# Altium schematic sheet Main_Schematic.SchDoc
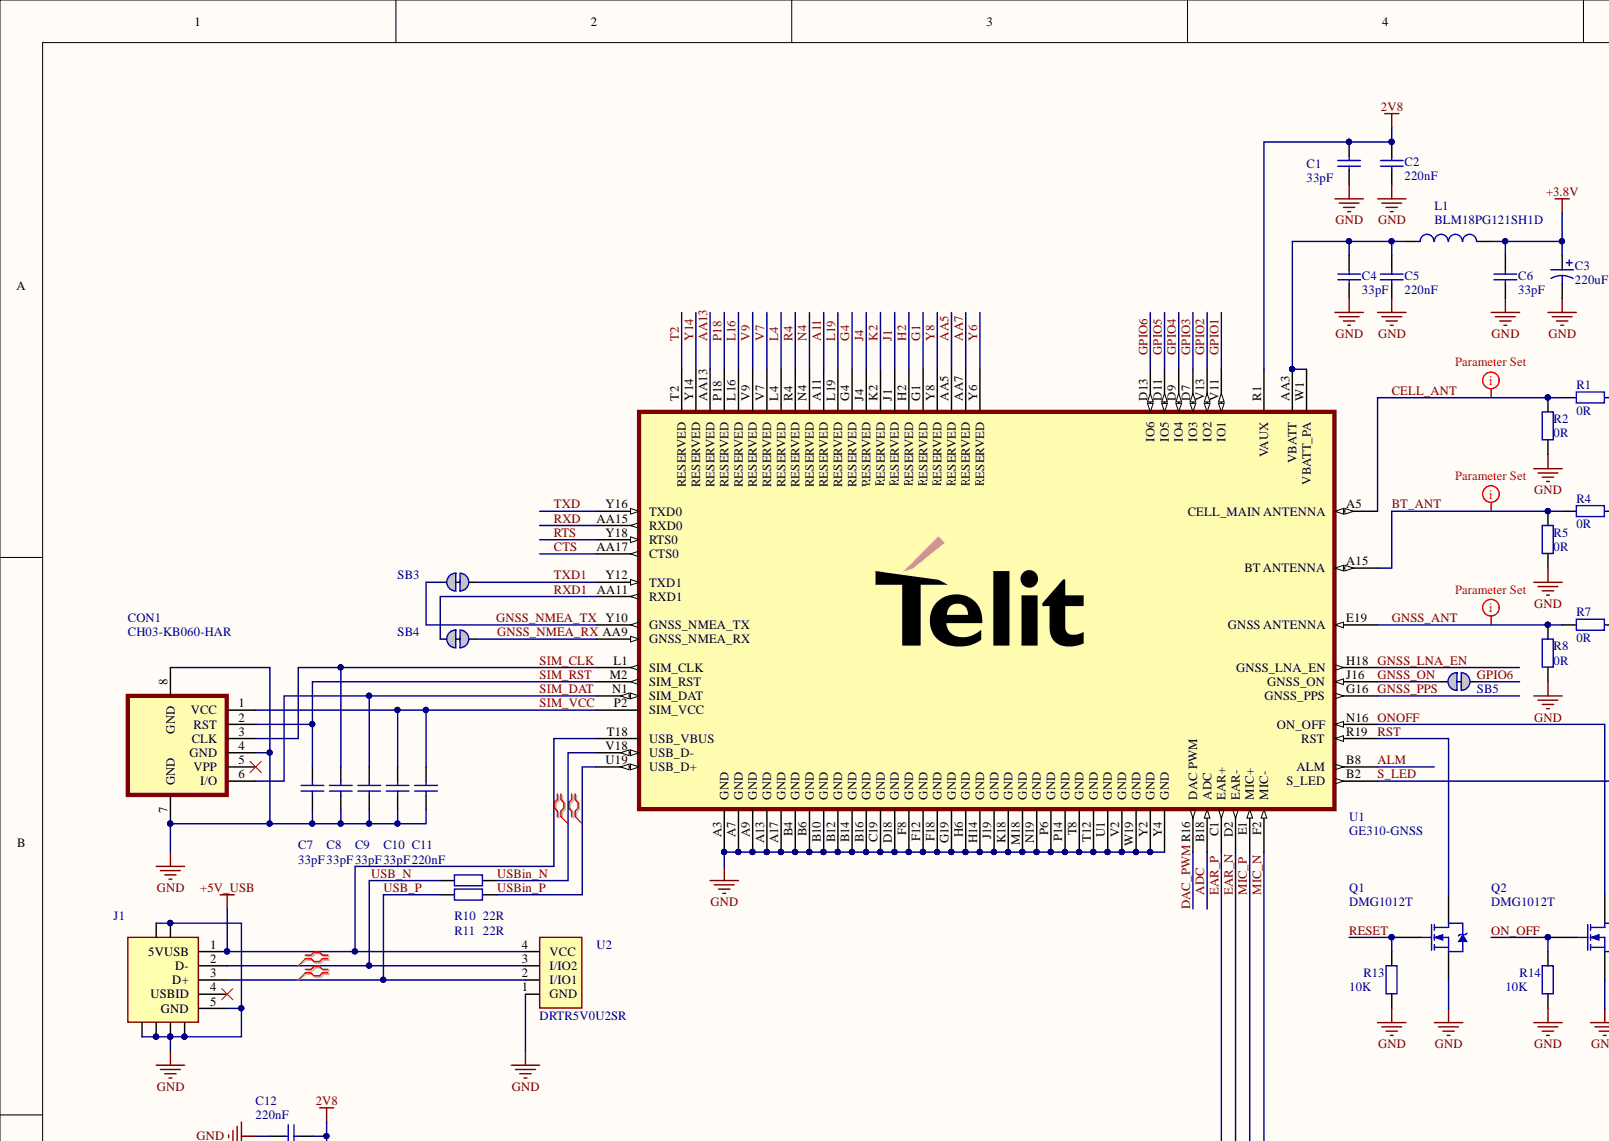
[diagram p0: Main_Schematic.SchDoc, top left]
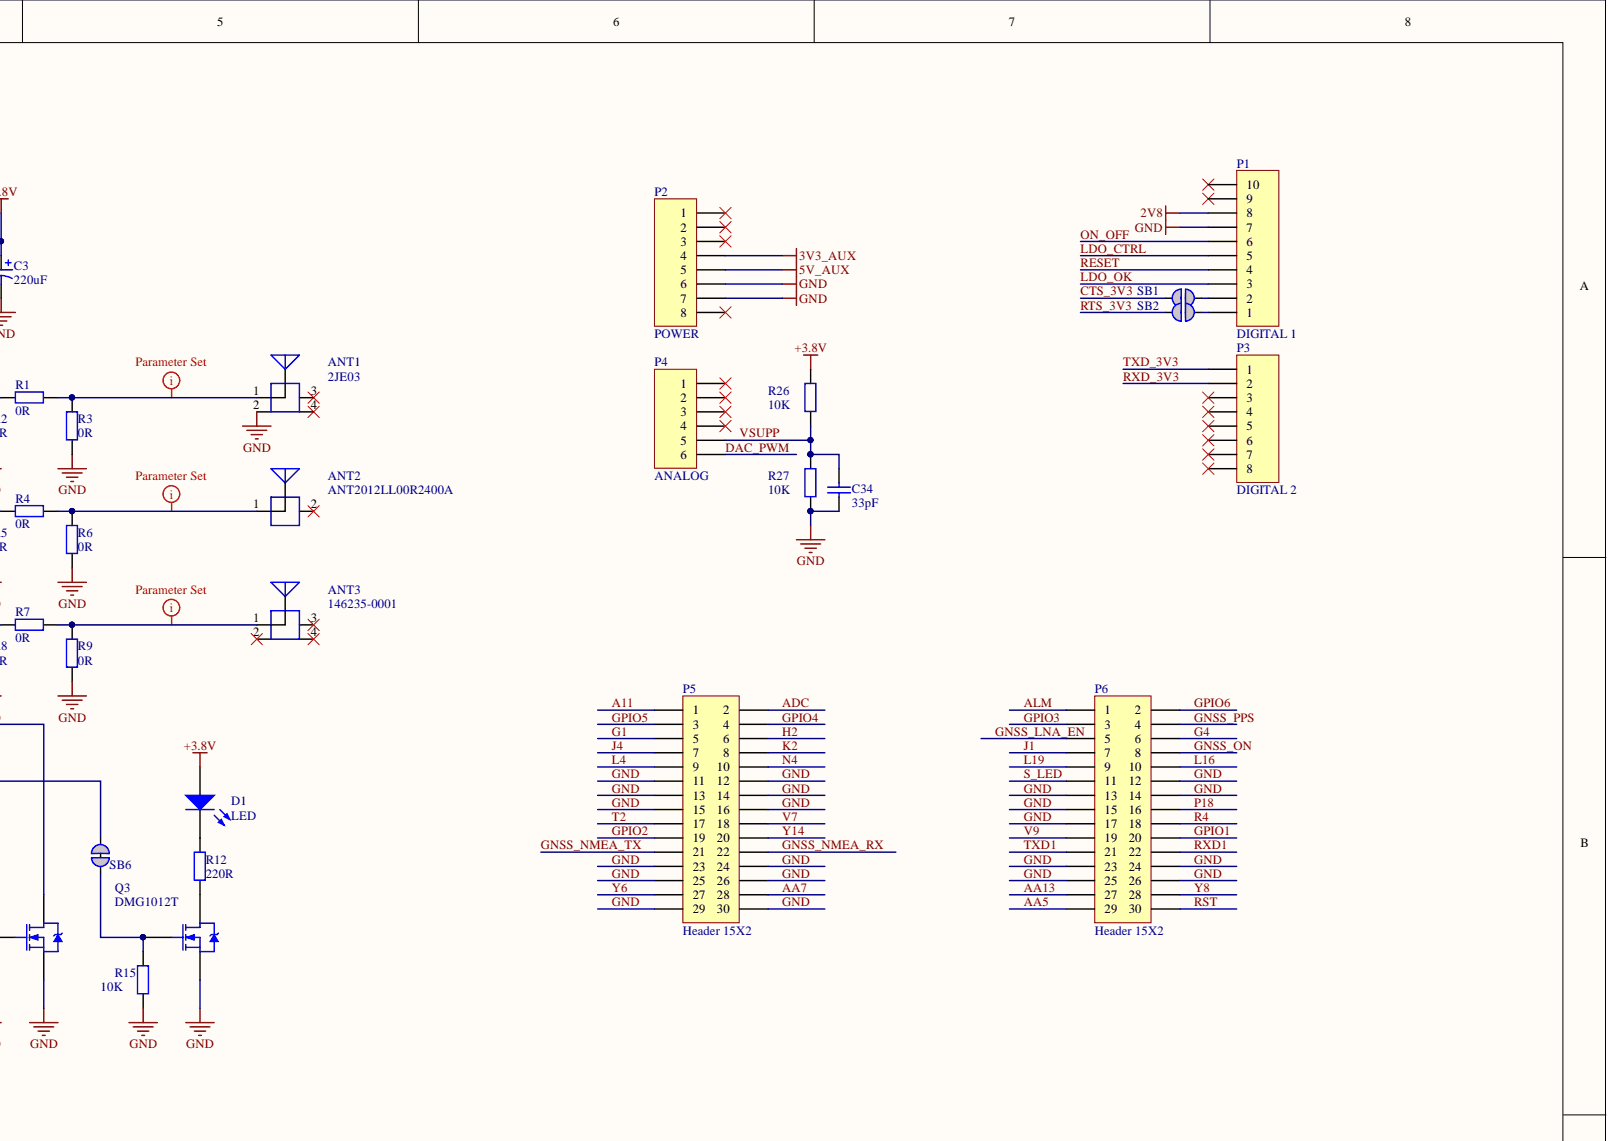
[diagram p1: Main_Schematic.SchDoc, top right]
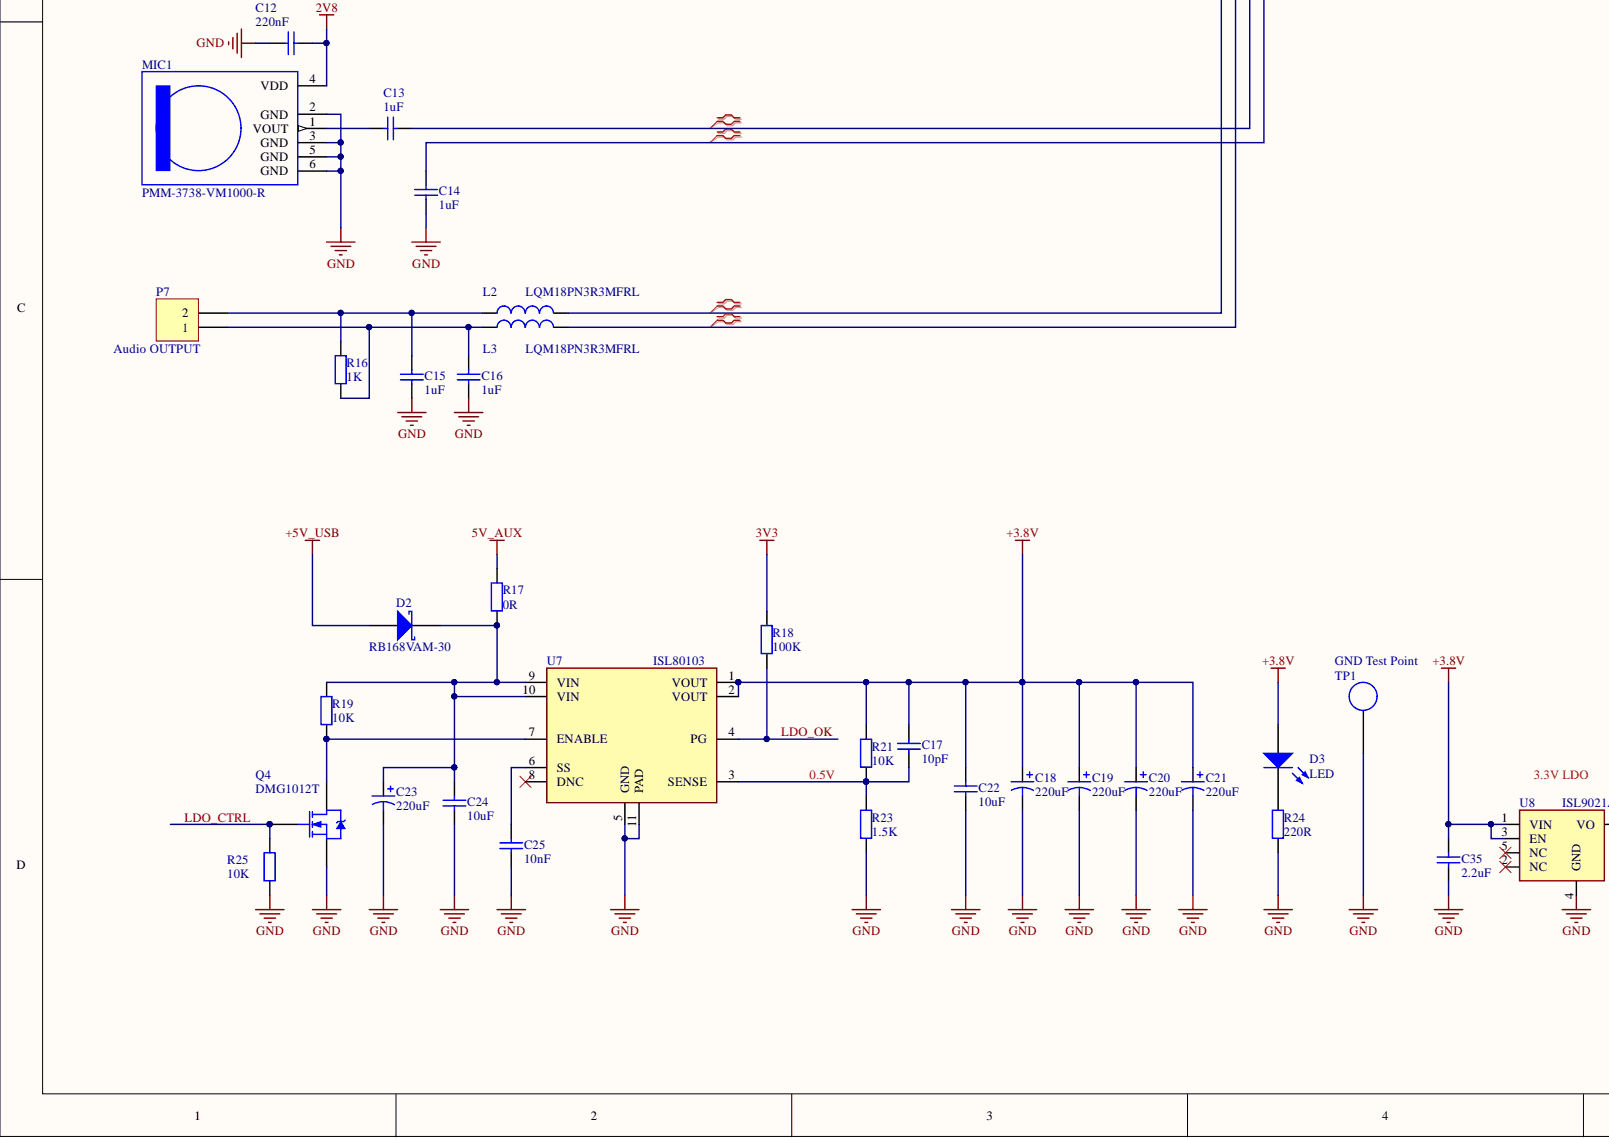
[diagram p2: Main_Schematic.SchDoc, bottom left]
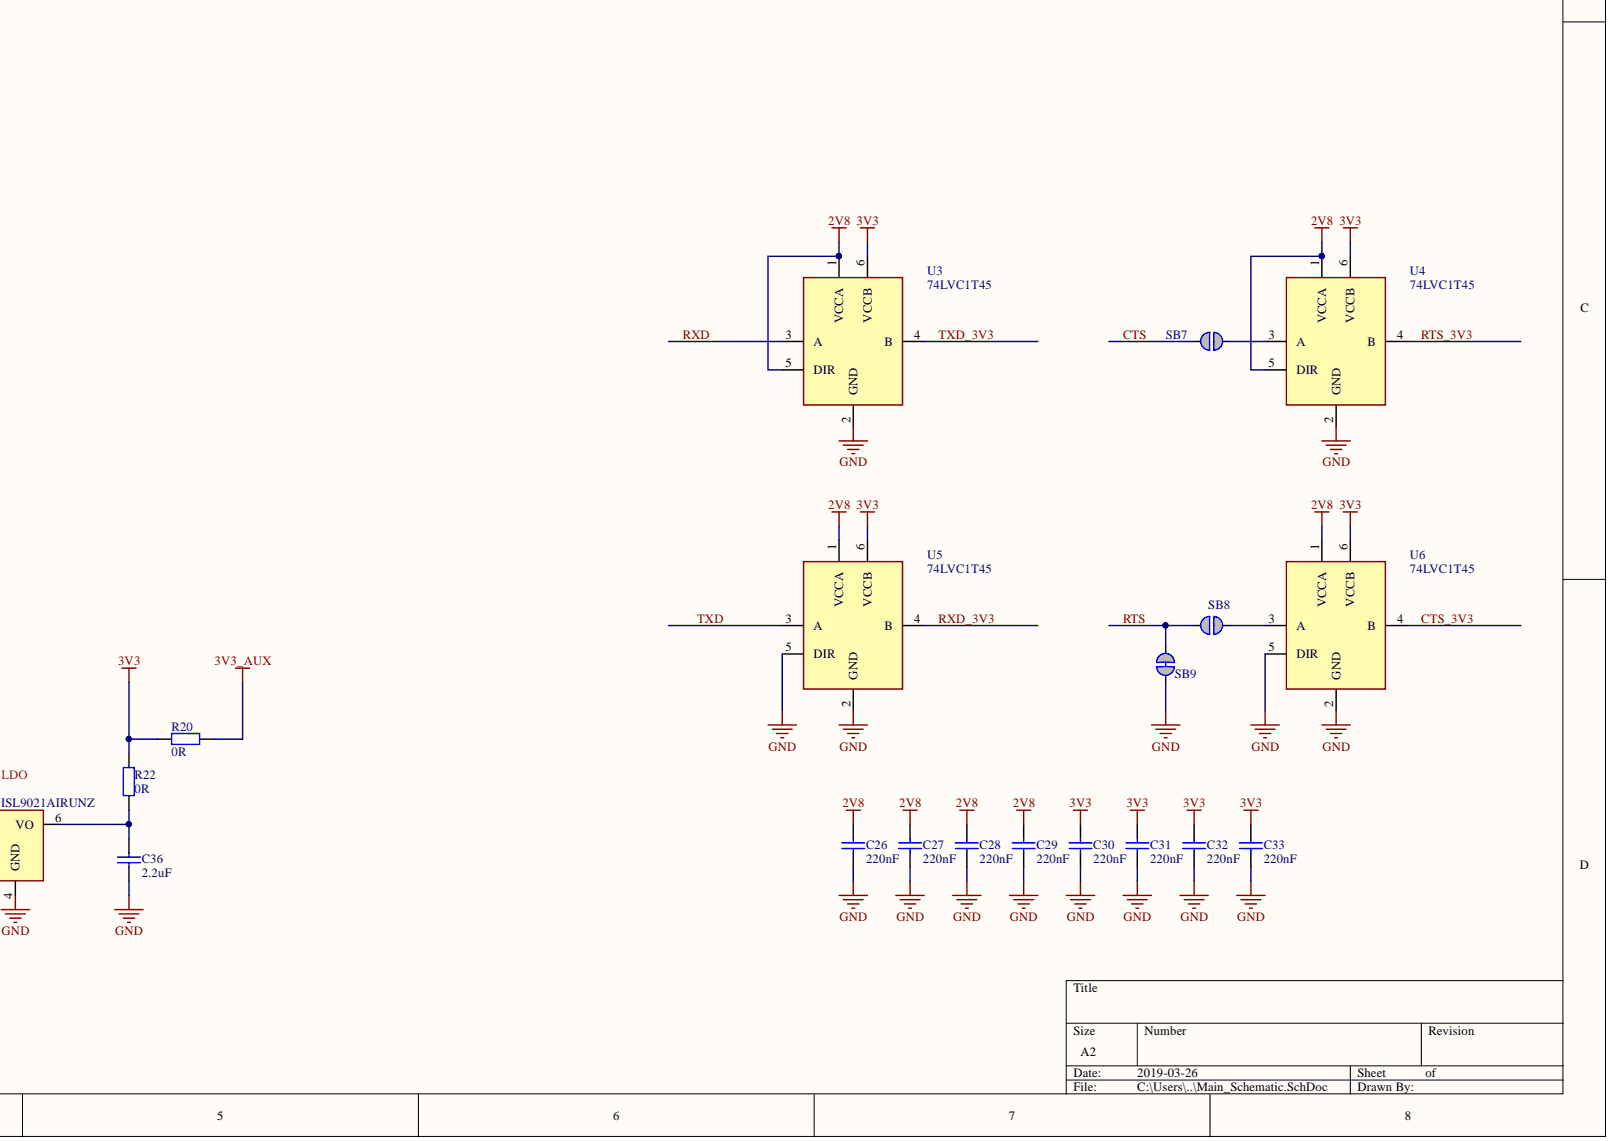
[diagram p3: Main_Schematic.SchDoc, bottom right]

## Parts
ANT1  2JE03  [2JE03]
ANT2  ANT2012LL00R2400A  [YEGEO_BT_Antena_0805]
ANT3  146235-0001  [Molex_GNSS_antenna_1462350001]
C1  value 33pF  [C0402]  (hidden comment: Cap Semi)
C2  value 220nF  [C0402]  (hidden comment: Cap Semi)
C3  value 220uF  [Case-B]  (hidden comment: electrolytic capacitor)
C4  value 33pF  [C0402]  (hidden comment: Cap Semi)
C5  value 220nF  [C0402]  (hidden comment: Cap Semi)
C6  value 33pF  [C0402]  (hidden comment: Cap Semi)
C7  value 33pF  [C0402]  (hidden comment: Cap Semi)
C8  value 33pF  [C0402]  (hidden comment: Cap Semi)
C9  value 33pF  [C0402]  (hidden comment: Cap Semi)
C10  value 33pF  [C0402]  (hidden comment: Cap Semi)
C11  value 220nF  [C0402]  (hidden comment: Cap Semi)
C12  value 220nF  [C0402]  (hidden comment: Cap Semi)
C13  value 1uF  [C0402]  (hidden comment: Cap Semi)
C14  value 1uF  [C0402]  (hidden comment: Cap Semi)
C15  value 1uF  [C0402]  (hidden comment: Cap Semi)
C16  value 1uF  [C0402]  (hidden comment: Cap Semi)
C17  value 10pF  [C0402]  (hidden comment: Cap Semi)
C18  value 220uF  [Case-B]  (hidden comment: electrolytic capacitor)
C19  value 220uF  [Case-B]  (hidden comment: electrolytic capacitor)
C20  value 220uF  [Case-B]  (hidden comment: electrolytic capacitor)
C21  value 220uF  [Case-B]  (hidden comment: electrolytic capacitor)
C22  value 10uF  [C0402]  (hidden comment: Cap Semi)
C23  value 220uF  [Case-B]  (hidden comment: electrolytic capacitor)
C24  value 10uF  [C0402]  (hidden comment: Cap Semi)
C25  value 10nF  [C0402]  (hidden comment: Cap Semi)
C26  value 220nF  [C0402]  (hidden comment: Cap Semi)
C27  value 220nF  [C0402]  (hidden comment: Cap Semi)
C28  value 220nF  [C0402]  (hidden comment: Cap Semi)
C29  value 220nF  [C0402]  (hidden comment: Cap Semi)
C30  value 220nF  [C0402]  (hidden comment: Cap Semi)
C31  value 220nF  [C0402]  (hidden comment: Cap Semi)
C32  value 220nF  [C0402]  (hidden comment: Cap Semi)
C33  value 220nF  [C0402]  (hidden comment: Cap Semi)
C34  value 33pF  [C0402]  (hidden comment: Cap Semi)
C35  value 2.2uF  [C0402]  (hidden comment: Cap Semi)
C36  value 2.2uF  [C0402]  (hidden comment: Cap Semi)
CON1  CH03-KB060-HAR  [CH03-KB]
D1  LED  [LED0805]
D2  RB168VAM-30  [SOD323]
D3  LED  [LED0805]
J1  CON-000116-01  [10103594_micro_USB]  (value not drawn)
L1  value BLM18PG121SH1D  Manufacturer Part No.: BLM18PG121SH1D  [L0603]  (hidden comment: Inductor)
L2  value LQM18PN3R3MFRL  Manufacturer Part No.: LQM18PN3R3MFRL  [L0603]  (hidden comment: Inductor)
L3  value LQM18PN3R3MFRL  Manufacturer Part No.: LQM18PN3R3MFRL  [L0603]  (hidden comment: Inductor)
MIC1  PMM-3738-VM1000-R  [PUI_MIC]
P1  DIGITAL 1  [HDR1X10]
P2  POWER  [HDR1X8]  (no BOM)
P3  DIGITAL 2  [HDR1X8]  (no BOM)
P4  ANALOG  [HDR1X6]  (no BOM)
P5  Header 15X2  [2X15_2mm_header]  (no BOM)
P6  Header 15X2  [2X15_2mm_header]  (no BOM)
P7  Audio OUTPUT  [104238-0210  Molex]
Q1  DMG1012T  [SOT-523_custom]
Q2  DMG1012T  [SOT-523_custom]
Q3  DMG1012T  [SOT-523_custom]
Q4  DMG1012T  [SOT-523_custom]
R1  value 0R  [R0402]  (hidden comment: Res2)
R2  value 0R  [R0402]  (hidden comment: Res2)
R3  value 0R  [R0402]  (hidden comment: Res2)
R4  value 0R  [R0402]  (hidden comment: Res2)
R5  value 0R  [R0402]  (hidden comment: Res2)
R6  value 0R  [R0402]  (hidden comment: Res2)
R7  value 0R  [R0402]  (hidden comment: Res2)
R8  value 0R  [R0402]  (hidden comment: Res2)
R9  value 0R  [R0402]  (hidden comment: Res2)
R10  value 22R  [R0402]  (hidden comment: Res2)
R11  value 22R  [R0402]  (hidden comment: Res2)
R12  value 220R  [R0402]  (hidden comment: Res2)
R13  value 10K  [R0402]  (hidden comment: Res2)
R14  value 10K  [R0402]  (hidden comment: Res2)
R15  value 10K  [R0402]  (hidden comment: Res2)
R16  value 1K  [R0402]  (hidden comment: Res2)
R17  value 0R  [R0402]  (hidden comment: Res2)
R18  value 100K  [R0402]  (hidden comment: Res2)
R19  value 10K  [R0402]  (hidden comment: Res2)
R20  value 0R  [R0402]  (hidden comment: Res2)
R21  value 10K  [R0402]  (hidden comment: Res2)
R22  value 0R  [R0402]  (hidden comment: Res2)
R23  value 1.5K  [R0402]  (hidden comment: Res2)
R24  value 220R  [R0402]  (hidden comment: Res2)
R25  value 10K  [R0402]  (hidden comment: Res2)
R26  value 10K  [R0402]  (hidden comment: Res2)
R27  value 10K  [R0402]  (hidden comment: Res2)
SB1  Solderbridge  [Solderbridge]  (value not drawn)  (no BOM)
SB2  Solderbridge  [Solderbridge]  (value not drawn)  (no BOM)
SB3  Solderbridge  [Solderbridge_Shorted]  (value not drawn)
SB4  Solderbridge  [Solderbridge_Shorted]  (value not drawn)
SB5  Solderbridge  [Solderbridge_Shorted]  (value not drawn)
SB6  Solderbridge  [Solderbridge_Shorted]  (value not drawn)
SB7  Solderbridge  [Solderbridge]  (value not drawn)  (no BOM)
SB8  Solderbridge  [Solderbridge]  (value not drawn)  (no BOM)
SB9  Solderbridge  [Solderbridge_Shorted]  (value not drawn)
TP1  GND Test Point  [5019_keystone]
U1  GE310-GNSS  [LGA_GE310]
U2  DRTR5V0U2SR  [SOT143M]
U3  74LVC1T45  [SOT23-6_custom]
U4  74LVC1T45  [SOT23-6_custom]
U5  74LVC1T45  [SOT23-6_custom]
U6  74LVC1T45  [SOT23-6_custom]
U7  ISL80103  [QFN50P300X300X100_HS-11N]
U8  ISL9021AIRUNZ  [uTDFN-6]

## Nets
2V8: C1.1 C2.2 C12.1 C26.1 C27.1 C28.1 C29.1 MIC1.4(VDD) P1.8 U1.R1(VAUX) U3.1(VCCA) U3.5(DIR) U4.1(VCCA) U4.5(DIR) U5.1(VCCA) U6.1(VCCA)
3V3: C30.1 C31.1 C32.1 C33.1 R18.2 R20.2 R22.2 U3.6(VCCB) U4.6(VCCB) U5.6(VCCB) U6.6(VCCB)
3V3_AUX: P2.4 R20.1
5V_AUX: P2.5 R17.1
+3.8V: C3.1 C6.2 C17.2 C18.1 C19.1 C20.1 C21.1 C22.2 C35.1 D1.1 D3.1 L1.2 R21.1 R26.2 U7.1(VOUT) U7.2(VOUT) U8.1(VIN) U8.3(EN)
+5V_USB: D2.1 J1.1(5VUSB) U1.T18(USB_VBUS) U2.4(VCC)
A11: P5.1 U1.A11(RESERVED)
AA5: P6.29 U1.AA5(RESERVED)
AA7: P5.28 U1.AA7(RESERVED)
AA13: P6.27 U1.AA13(RESERVED)
ADC: P5.2 U1.B18(ADC)
ALM: P6.1 U1.B8(ALM)
BT_ANT: R4.2 R5.1 U1.A15(BT_ANTENNA)
CELL_ANT: R1.2 R2.1 U1.A5(CELL_MAIN_ANTENNA)
CTS: SB7.1 U1.AA17(CTS0)
CTS_3V3: SB1.1 U6.4(B)
DAC_PWM: P4.6 U1.R16(DAC_PWM)
EAR_N: L3.2 U1.D2(EAR-)
EAR_P: L2.2 U1.C1(EAR+)
G1: P5.5 U1.G1(RESERVED)
G4: P6.6 U1.G4(RESERVED)
GND: ANT1.2 C1.2 C2.1 C3.2 C4.1 C5.1 C6.1 C7.2 C8.2 C9.2 C10.2 C11.1 C12.2 C14.2 C15.1 C16.1 C18.2 C19.2 C20.2 C21.2 C22.1 C23.2 C24.1 C25.1 C26.2 C27.2 C28.2 C29.2 C30.2 C31.2 C32.2 C33.2 C34.1 C35.2 C36.2 CON1.4(GND) CON1.7(GND) CON1.8(GND) J1.5(GND) J1.6 J1.7 J1.8 J1.9 J1.10 J1.11 MIC1.2(GND) MIC1.3(GND) MIC1.5(GND) MIC1.6(GND) P1.7 P2.6 P2.7 P5.11 P5.12 P5.13 P5.14 P5.15 P5.16 P5.23 P5.24 P5.25 P5.26 P5.29 P5.30 P6.12 P6.13 P6.14 P6.15 P6.17 P6.23 P6.24 P6.25 P6.26 Q1.3 Q2.3 Q3.3 Q4.3 R2.2 R3.2 R5.2 R6.2 R8.2 R9.2 R13.1 R14.1 R15.1 R23.2 R24.2 R25.1 R27.1 SB9.2 TP1.1 U1.A3(GND) U1.A7(GND) U1.A9(GND) U1.A13(GND) U1.A17(GND) U1.B4(GND) U1.B6(GND) U1.B10(GND) U1.B12(GND) U1.B14(GND) U1.B16(GND) U1.C19(GND) U1.D18(GND) U1.F8(GND) U1.F12(GND) U1.F18(GND) U1.G19(GND) U1.H6(GND) U1.H14(GND) U1.J19(GND) U1.K18(GND) U1.M18(GND) U1.N19(GND) U1.P6(GND) U1.P14(GND) U1.T8(GND) U1.T12(GND) U1.U1(GND) U1.V2(GND) U1.W19(GND) U1.Y2(GND) U1.Y4(GND) U2.1(GND) U3.2(GND) U4.2(GND) U5.2(GND) U5.5(DIR) U6.2(GND) U6.5(DIR) U7.5(GND) U7.11(PAD) U8.4(GND)
GNSS_ANT: R7.2 R8.1 U1.E19(GNSS_ANTENNA)
GNSS_LNA_EN: P6.5 U1.H18(GNSS_LNA_EN)
GNSS_NMEA_RX: P5.22 SB4.1 U1.AA9(GNSS_NMEA_RX)
GNSS_NMEA_TX: P5.21 SB3.2 U1.Y10(GNSS_NMEA_TX)
GNSS_ON: P6.8 SB5.1 U1.J16(GNSS_ON)
GNSS_PPS: P6.4 U1.G16(GNSS_PPS)
GPIO1: P6.20 U1.V11(IO1)
GPIO2: P5.19 U1.V13(IO2)
GPIO3: P6.3 U1.D7(IO3)
GPIO4: P5.4 U1.D9(IO4)
GPIO5: P5.3 U1.D11(IO5)
GPIO6: P6.2 SB5.2 U1.D13(IO6)
H2: P5.6 U1.H2(RESERVED)
J1: P6.7 U1.J1(RESERVED)
J4: P5.7 U1.J4(RESERVED)
K2: P5.8 U1.K2(RESERVED)
L4: P5.9 U1.L4(RESERVED)
L16: P6.10 U1.L16(RESERVED)
L19: P6.9 U1.L19(RESERVED)
LDO_CTRL: P1.5 Q4.1 R25.2
LDO_OK: P1.3 R18.1 U7.4(PG)
MIC_N: C14.1 U1.F2(MIC-)
MIC_P: C13.1 U1.E1(MIC+)
N4: P5.10 U1.N4(RESERVED)
ON_OFF: P1.6 Q2.1 R14.2
ONOFF: Q2.2 U1.N16(ON_OFF)
P18: P6.16 U1.P18(RESERVED)
R4: P6.18 U1.R4(RESERVED)
RESET: P1.4 Q1.1 R13.2
RST: P6.30 Q1.2 U1.R19(RST)
RTS: SB8.1 SB9.1 U1.Y18(RTS0)
RTS_3V3: SB2.1 U4.4(B)
RXD: U1.AA15(RXD0) U3.3(A)
RXD1: P6.22 SB4.2 U1.AA11(RXD1)
RXD_3V3: P3.2 U5.4(B)
S_LED: P6.11 SB6.2 U1.B2(S_LED)
SIM_CLK: C8.1 CON1.3(CLK) U1.L1(SIM_CLK)
SIM_DAT: C9.1 CON1.6(I/O) U1.N1(SIM_DAT)
SIM_RST: C7.1 CON1.2(RST) U1.M2(SIM_RST)
SIM_VCC: C10.1 C11.2 CON1.1(VCC) U1.P2(SIM_VCC)
T2: P5.17 U1.T2(RESERVED)
TXD: U1.Y16(TXD0) U5.3(A)
TXD1: P6.21 SB3.1 U1.Y12(TXD1)
TXD_3V3: P3.1 U3.4(B)
USB_N: J1.2(D-) R10.1 U2.3(I/IO2)
USB_P: J1.3(D+) R11.1 U2.2(I/IO1)
USBin_N: R10.2 U1.V18(USB_D-)
USBin_P: R11.2 U1.U19(USB_D+)
V7: P5.18 U1.V7(RESERVED)
V9: P6.19 U1.V9(RESERVED)
VSUPP: C34.2 P4.5 R26.1 R27.2
Y6: P5.27 U1.Y6(RESERVED)
Y8: P6.28 U1.Y8(RESERVED)
Y14: P5.20 U1.Y14(RESERVED)
NetANT1_1: ANT1.1 R1.1 R3.1
NetANT2_1: ANT2.1 R4.1 R6.1
NetANT3_1: ANT3.1 R7.1 R9.1
NetC4_2: C4.2 C5.2 L1.1 U1.AA3(VBATT) U1.W1(VBATT_PA)
NetC13_2: C13.2 MIC1.1(VOUT)
NetC15_2: C15.2 L2.1 P7.2 R16.1
NetC16_2: C16.2 L3.1 P7.1 R16.2
NetC17_1: C17.1 R21.2 R23.1 U7.3(SENSE)
NetC23_1: C23.1 C24.2 D2.2 R17.2 R19.1 U7.9(VIN) U7.10(VIN)
NetC25_2: C25.2 U7.6(SS)
NetC36_1: C36.1 R22.1 U8.6(VO)
NetD1_2: D1.2 R12.1
NetD3_2: D3.2 R24.1
NetP1_1: P1.1 SB2.2
NetP1_2: P1.2 SB1.2
NetQ3_1: Q3.1 R15.2 SB6.1
NetQ3_2: Q3.2 R12.2
NetQ4_2: Q4.2 R19.2 U7.7(ENABLE)
NetSB7_2: SB7.2 U4.3(A)
NetSB8_2: SB8.2 U6.3(A)

Not connected: ANT1 3 4; ANT2 2; ANT3 2 3 4; CON1 5(VPP); J1 4(USBID); P1 9 10; P2 1 2 3 8; P3 3 4 5 6 7 8; P4 1 2 3 4; U7 8(DNC); U8 2(NC) 5(NC)

## Library data of the parts
ANT1 = Antenna: Generic Antenna; Manufacturer: 2J; Part Description: Embedded Dielectric Penta-Band Antenna; Specification: GSM850 , GSM900 , DCS , PCS , UMTS; Component Kind: Standard; Link: https://www.rutronik24.com/product/2j/2je03/947864.html; Manufacturer Part No.: 2JE03; Supplier: Rutronik; Supplier part number: WIREAC1581; Value: 2JE03; Library Name: RUT_GE310_GNSS.SCHLIB
ANT2 = Antenna: Generic Antenna; Manufacturer: YAGEO; Part Description: Ceramic Chip Antenna; Specification: 2.4 – 2.5 GHZ; Component Kind: Standard; Link: https://www.rutronik24.com/product/yageo/ant2012ll00r2400a/42235.html; Manufacturer Part No.: ANT2012LL00R2400A; Supplier: Rutronik; Supplier part number: WIREAC1413; Value: ANT2012LL00R2400A; Library Name: RUT_GE310_GNSS.SCHLIB
ANT3 = Antenna: Generic Antenna; Manufacturer: Molex; Part Description: Helix SMT GPS Antenna; Specification: 1.561 –1.602 GHZ; Component Kind: Standard; Link: https://www.molex.com/molex/products/datasheet.jsp?part=active/1462350001_ANTENNAS.xml; Manufacturer Part No.: 146235-0001; Supplier: Rutronik; Value: 146235-0001; Library Name: RUT_GE310_GNSS.SCHLIB
C1 C4 C6-C10 C34 = Cap Semi: Capacitor (Semiconductor SIM Model); Manufacturer: AVX; Manufacturer Part No.: 04025A330JAT2A; Part Description: Ceramic Capacitor; Specification: NP0, 6.3V, 5%; Component Kind: Standard; Library Name: Miscellaneous Devices.IntLib; Link: https://www.rutronik24.com/product/avx/04025a330jat2a/3692730.html; Signal Integrity: Cap Semi; Simulation: CAP; Supplier: Rutronik; Supplier part number: KKK13594
C2 C5 C11 C12 = Cap Semi: Capacitor (Semiconductor SIM Model); Manufacturer: YAGEO; Manufacturer Part No.: CC0402KRX5R5BB224; Part Description: Ceramic Capacitor; Specification: NP0, 6.3V, 5%; Component Kind: Standard; Library Name: Miscellaneous Devices.IntLib; Link: https://www.rutronik24.com/product/yageo/cc0402krx5r5bb224/3611951.html; Signal Integrity: Cap Semi; Simulation: CAP; Supplier: Rutronik; Supplier part number: KKK23148
C3 C18-C21 C23 = Cap Pol1: Manufacturer: VISHAY; Manufacturer Part No.: T55B227M6R3C0025; Part Description: Tantalum Polymer Capacitor; Specification: 6,3V 20%; Component Kind: Standard; Library Name: Miscellaneous Devices.IntLib; Link: https://www.rutronik24.com/product/vishay/t55b227m6r3c0025/8345892.html; Signal Integrity: Cap Pol; Simulation: CAP; Supplier: Rutronik; Supplier part number: KTA8036
C13-C16 = Cap Semi: Capacitor (Semiconductor SIM Model); Manufacturer: Samsung Electronics; Manufacturer Part No.: CL05A105KQ5NNNC; Part Description: Ceramic Capacitor; Specification: X5R, 6.3V, 10%; Component Kind: Standard; Library Name: Miscellaneous Devices.IntLib; Link: https://www.rutronik24.com/product/samsung+em/cl05a105kq5nnnc/30701.html; Signal Integrity: Cap Semi; Simulation: CAP; Supplier: Rutronik; Supplier part number: KKK18264
C17 = Cap Semi: Capacitor (Semiconductor SIM Model); Manufacturer: AVX; Manufacturer Part No.: 0402YA100KAT2A; Part Description: Ceramic Capacitor; Specification: NP0, 6.3V, 5%; Component Kind: Standard; Library Name: Miscellaneous Devices.IntLib; Link: https://www.rutronik24.com/product/avx/0402ya100kat2a/7135478.html; Signal Integrity: Cap Semi; Simulation: CAP; Supplier: Rutronik; Supplier part number: KKK24028
C22 C24 = Cap Semi: Capacitor (Semiconductor SIM Model); Manufacturer: Samsung Electronics; Manufacturer Part No.: CL05A106MP5NUNC; Part Description: Ceramic Capacitor; Specification: X5R, 6.3V, 20%; Component Kind: Standard; Library Name: Miscellaneous Devices.IntLib; Link: https://www.rutronik24.com/product/samsung+em/cl05a106mp5nunc/32408.html; Signal Integrity: Cap Semi; Simulation: CAP; Supplier: Rutronik; Supplier part number: KKS2857
C25 = Cap Semi: Capacitor (Semiconductor SIM Model); Manufacturer: Samsung Electronics; Manufacturer Part No.: CL05B103KO5NNNC; Part Description: Ceramic Capacitor; Specification: X5R, 6.3V, 10%; Component Kind: Standard; Library Name: Miscellaneous Devices.IntLib; Link: https://www.rutronik24.com/product/samsung+em/cl05b103ko5nnnc/6952227.html; Signal Integrity: Cap Semi; Simulation: CAP; Supplier: Rutronik; Supplier part number: KKK22033
C26-C33 = Cap Semi: Capacitor (Semiconductor SIM Model); Manufacturer: YAGEO; Manufacturer Part No.: CC0402KRX5R5BB224; Part Description: Ceramic Capacitor; Specification: X5R, 6.3V, 10%; Component Kind: Standard; Library Name: Miscellaneous Devices.IntLib; Link: https://www.rutronik24.com/product/yageo/cc0402krx5r5bb224/3611951.html; Signal Integrity: Cap Semi; Simulation: CAP; Supplier: Rutronik; Supplier part number: KKK23148
C35 C36 = Cap Semi: Capacitor (Semiconductor SIM Model); Manufacturer: Samsung Electronics; Manufacturer Part No.: CL05A225KQ5NSNC; Part Description: Ceramic Capacitor; Specification: X5R, 6.3V, 10%; Component Kind: Standard; Library Name: Miscellaneous Devices.IntLib; Link: https://www.rutronik24.com/product/samsung+em/cl05a225kq5nsnc/31783.html; Signal Integrity: Cap Semi; Simulation: CAP; Supplier: Rutronik; Supplier part number: KKS1045
CON1 = CH03-KB060-HAR: Nano SIM Card Connector; Manufacturer: GradConn; Specification: Nano, 6 pins; Component Kind: Standard; Library Name: CH03-KB060-HAR.SchLib; Link: https://www.rutronik24.com/product/gradconn/ch03-kb060-har/7026333.html; Manufacturer Part No.: CH03-KB060-HAR; Part Description: Nano SIM Card Connector; Supplier: Rutronik; Supplier part number: SIMCRD1002; Value: CH03-KB060-HAR
D1 D3 = LED2: Typical SMD LED; Manufacturer: LITE ON; Manufacturer Part No.: LTST-C170KGKT; Specification: Green, 20mA; Value: LTST-C170KGKT; Component Kind: Standard; Library Name: Miscellaneous Devices.IntLib; Link: https://www.rutronik24.com/product/liteon/ltst-c170kgkt/33908.html; Part Description: Typical SMD LED; Simulation: LED2; Supplier: Rutronik; Supplier part number: LED7323
D2 = D Schottky: Schottky Diode; Manufacturer: ROHM; Part Description: Shottky Barrier Diode; Specification: 30V, 1A; Component Kind: Standard; Library Name: Miscellaneous Devices.IntLib; Link: http://rohmfs.rohm.com/en/products/databook/datasheet/discrete/diode/schottky_barrier/rb168vam-30tr-e.pdf; Manufacturer Part No.: RB168VAM-30; Signal Integrity: D Schottky; Simulation: SKYDIODE; Supplier: Rutronik; Value: RB168VAM-30
J1 = CON-000116-01: Manufacturer: FCI; Manufacturer Part No.: 10103594-0001LF; Part Description: SMD Micro USB Connector; Specification: Type B; Value: 10103594-0001LF; Component Kind: Standard; Link: https://www.rutronik24.com/product/amph+icc/10103594-0001lf/1536675.html; Supplier: Rutronik; Supplier part number: CONIO1038; Library Name: RUT_L865_Project_1.SCHLIB
L1 = Inductor: Manufacturer: muRata; Part Description: Ferrite Bead; Specification: 120R, 2A; Component Kind: Standard; Description: Inductor; Library Name: Miscellaneous Devices.IntLib; Link: https://www.rutronik24.com/product/murata/blm18pg121sh1d/5885588.html; Signal Integrity: Inductor; Simulation: INDUCTOR; Supplier: Rutronik; Supplier part number: FE3867
L2 L3 = Inductor: Manufacturer: muRata; Part Description: Chip Inductor; Specification: 3.3uH, 700mA; Component Kind: Standard; Description: Inductor; Library Name: Miscellaneous Devices.IntLib; Link: https://www.rutronik24.com/product/murata/lqm18pn3r3mghd/8429260.html; Signal Integrity: Inductor; Simulation: INDUCTOR; Supplier: Rutronik; Supplier part number: IND17659
MIC1 = PMM-3738-VM1000-R: Low-Noise Bottom Port Piezoelectric MEMS Microphone; Manufacturer: PUI Audio; Specification: -38dB, 1.8V,  0.165mA; Component Kind: Standard; Library Name: PMM-3738-VM1000-R.SchLib; Link: https://www.rutronik24.com/product/pui+audio/pmm-3738-vm1000-r/8515165.html; Manufacturer Part No.: PMM-3738-VM1000-R; Part Description: Piezoelectric MEMS Microphone; Supplier: Rutronik; Supplier part number: PIEZO4565; Value: PMM-3738-VM1000-R
P1 = Header 10: Manufacturer: SparkFun Electronics; Manufacturer Part No.: PRT-11417; Part Description: Arduino Stackable Header Kit; Specification: 10 pins; Value: PRT-11417; Component Kind: Standard; Library Name: Miscellaneous Connectors.IntLib; Link: https://www.digikey.com/products/en?keywords=1568-1413-ND; Signal Integrity: Connector; Supplier: Digi-Key; Supplier part number: 1568-1413-ND
P2 P3 = Header 8: Part Description: Arduino Connector; Specification: 8 pins; Library Name: Miscellaneous Connectors.IntLib; Signal Integrity: Connector
P4 = Header 6: Part Description: Arduino Connector; Specification: 6 pins; Library Name: Miscellaneous Connectors.IntLib; Signal Integrity: Connector
P5 P6 = Header 15X2: Header, 15-Pin, Dual row; Part Description: IO Extension; Specification: 30 pins,  2 rows, 2mm pitch; Library Name: Miscellaneous Connectors.IntLib; Signal Integrity: Connector
P7 = Header 2: Manufacturer: Molex; Manufacturer Part No.: 104238-0210; Part Description: Terminal; Specification: 2 pins, 5 mm pitch; Value: 104238-0210; Component Kind: Standard; Library Name: Miscellaneous Connectors.IntLib; Link: https://www.molex.com/molex/products/datasheet.jsp?part=active/1042380210_PCB_RECEPTACLES.xml; Signal Integrity: Connector; Supplier: Rutronik; Supplier part number: COREC38239
Q1-Q4 = NMOS-2: N-Channel Power MOSFET; Manufacturer: Diodes Inc.; Manufacturer Part No.: DMG1012T-7; Part Description: N-Channel MOSFET; Specification: 20V, 0.63A; Value: DMG1012T-7; Component Kind: Standard; Library Name: Miscellaneous Devices.IntLib; Link: https://www.rutronik24.com/product/diodes+inc/dmg1012t-7/5168665.html; Simulation: IRF1010; Supplier: Rutronik; Supplier part number: TMOSS6623
R1-R9 R17 R20 R22 = Res2: Manufacturer: YAGEO; Manufacturer Part No.: RC0402FR-070RL; Part Description: Thick Film Resistor; Specification: 5% 0,063W; Component Kind: Standard; Library Name: Miscellaneous Devices.IntLib; Link: https://www.rutronik24.com/product/yageo/rc0402fr-070rl/5183530.html; Signal Integrity: Res; Simulation: RESISTOR; Supplier: Rutronik; Supplier part number: WRC53079
R10 R11 = Res2: Manufacturer: ASJ; Manufacturer Part No.: CR10-220-JK; Part Description: Thick Film Resistor; Specification: 5% 0,063W; Component Kind: Standard; Library Name: Miscellaneous Devices.IntLib; Link: https://www.rutronik24.com/product/asj/cr10-220-jk/51754.html; Signal Integrity: Res; Simulation: RESISTOR; Supplier: Rutronik; Supplier part number: WRC34862
R12 R24 = Res2: Manufacturer: ASJ; Manufacturer Part No.: CR10-221-JK; Part Description: Thick Film Resistor; Specification: 5% 0,063W; Component Kind: Standard; Library Name: Miscellaneous Devices.IntLib; Link: https://www.rutronik24.com/product/asj/cr10-221-jk/51883.html; Signal Integrity: Res; Simulation: RESISTOR; Supplier: Rutronik; Supplier part number: WRC35108
R13-R15 R19 R21 R25-R27 = Res2: Manufacturer: ASJ; Manufacturer Part No.: CR10-103-JK; Part Description: Thick Film Resistor; Specification: 5% 0,063W; Component Kind: Standard; Library Name: Miscellaneous Devices.IntLib; Link: https://www.rutronik24.com/product/asj/cr10-103-jk/54295.html; Signal Integrity: Res; Simulation: RESISTOR; Supplier: Rutronik; Supplier part number: WRC40976
R16 = Res2: Manufacturer: ASJ; Manufacturer Part No.: CR10-102-JK; Part Description: Thick Film Resistor; Specification: 5% 0,063W; Component Kind: Standard; Library Name: Miscellaneous Devices.IntLib; Link: https://www.rutronik24.com/product/asj/cr10-102-jk/54392.html; Signal Integrity: Res; Simulation: RESISTOR; Supplier: Rutronik; Supplier part number: WRC41426
R18 = Res2: Manufacturer: ASJ; Manufacturer Part No.: CR10-104-JK; Part Description: Thick Film Resistor; Specification: 5% 0,063W; Component Kind: Standard; Library Name: Miscellaneous Devices.IntLib; Link: https://www.rutronik24.com/product/asj/cr10-104-jk/51752.html; Signal Integrity: Res; Simulation: RESISTOR; Supplier: Rutronik; Supplier part number: WRC34858
R23 = Res2: Manufacturer: YAGEO; Manufacturer Part No.: RC0402JR-071K5L; Part Description: Thick Film Resistor; Specification: 5% 0,063W; Component Kind: Standard; Library Name: Miscellaneous Devices.IntLib; Link: https://www.rutronik24.com/product/yageo/rc0402jr-071k5l/51006.html; Signal Integrity: Res; Simulation: RESISTOR; Supplier: Rutronik; Supplier part number: WRC33836
SB3-SB6 SB9 = Solderbridge Shorted: Component Kind: Net Tie; Value: Solderbridge
TP1 = 5019: SMD Test Point; Manufacturer: Keystone Electrincs; Part Description: SMT Test Point; Specification: 3.81*2.03; Value: 5019; Component Kind: Standard; Library Name: test_point.SchLib; Link: https://www.rutronik24.com/product/keystone/pn5019/7028403.html; Manufacturer Part No.: 5019; Supplier: Rutronik; Supplier part number: BAT4950
U1 = GE310-GNSS: GE310-GNSS Cellular Modem; Manufacturer: Telit; Part Description: Cellular Module; Specification: GSM/GPRS 4-BAND +BT4.0 +GNSS; Component Kind: Standard; Library Name: GE310-GNSS.SchLib; Link: https://www.rutronik24.com/search-result/nojs:1337/qs:GSM1901/reset:0; Manufacturer Part No.: GE310-GNSS; Supplier: Rutronik; Supplier part number: GSM1901; Value: GE310-GNSS
U2 = DRTR5V0U2SR: 2 Channel Low Capacitance TVS Diode Array; Manufacturer: Diodes Inc.; Specification: 5A, 400mW; Component Kind: Standard; Library Name: DRTR5V0U2SR.SchLib; Link: https://www.rutronik24.com/product/diodes+inc/drtr5v0u2sr-7/5168977.html; Manufacturer Part No.: DRTR5V0U2SR; Part Description: TVS Diode Array; Signal Integrity: Guessed Model; Supplier: Rutronik; Supplier part number: DTRL10538; Value: DRTR5V0U2SR
U3-U6 = 74LVC1T45: Manufacturer: Diodes Inc.; Manufacturer Part No.: 74LVC1T45W6-7; Part Description: Single Bit Voltage Level Translator; Specification: 5.5V, 1.65V; Value: 74LVC1T45W6-7; Component Kind: Standard; Library Name: 74LVC1T45.SchLib; Link: https://www.rutronik24.com/product/diodes+inc/74lvc1t45w6-7/7622634.html; Supplier: Rutronik; Supplier part number: ICLOG10709
U7 = ISL80103: Manufacturer: Renesas; Manufacturer Part No.: ISL80103IRAJZ; Part Description: High Performance  3A Linear Regulator; Specification: 3A, 6V,  130 mV; Value: ISL80103IRAJZ; Component Kind: Standard; Link: https://www.rutronik24.com/product/intersil/isl80103irajz/3582889.html; Supplier: Rutronik; Supplier part number: ICSPR9341; Library Name: RUT_L865_Project_1.SCHLIB
U8 = ISL9021A: LDO; Manufacturer: Renesas; Part Description: 250mA Single LDO; Specification: 3.3V, 250mA; Component Kind: Standard; Library Name: ISL9021A.SchLib; Link: https://www.rutronik24.com/product/intersil/isl9021airunz-t/8547102.html; Manufacturer Part No.: ISL9021AIRUNZ; Supplier: Rutronik; Value: ISL9021AIRUNZ
SB1 SB2 SB7 SB8 = Solderbridge: Value: Solderbridge
